# Altium schematic sheet ARK_CAN_GPS_External_Interfaces_Power.SchDoc(ARK_CAN_GPS_External_Interfaces_Power)
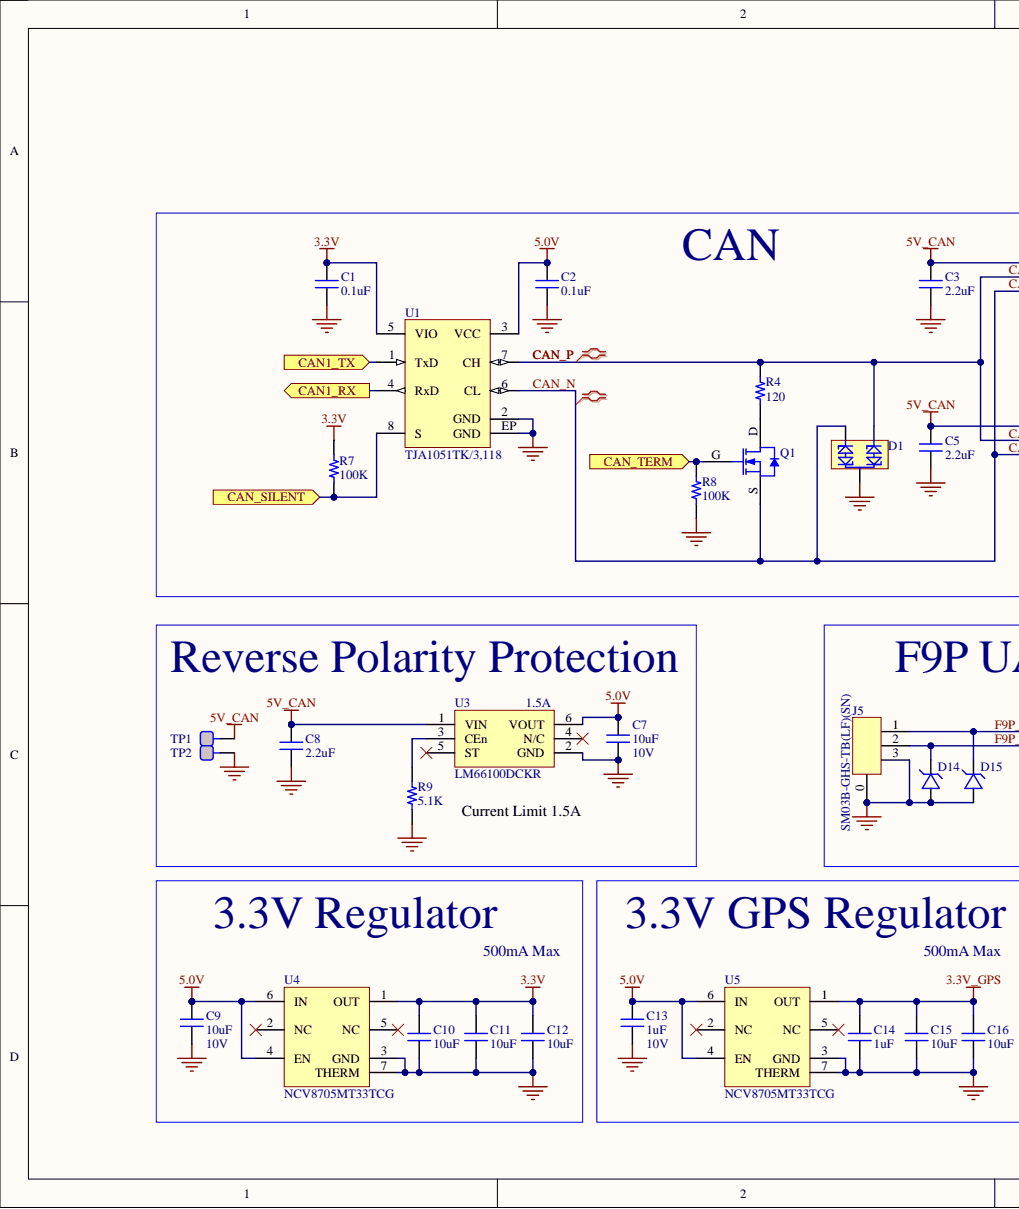
[diagram p0: ARK_CAN_GPS_External_Interfaces_Power.SchDoc, left]
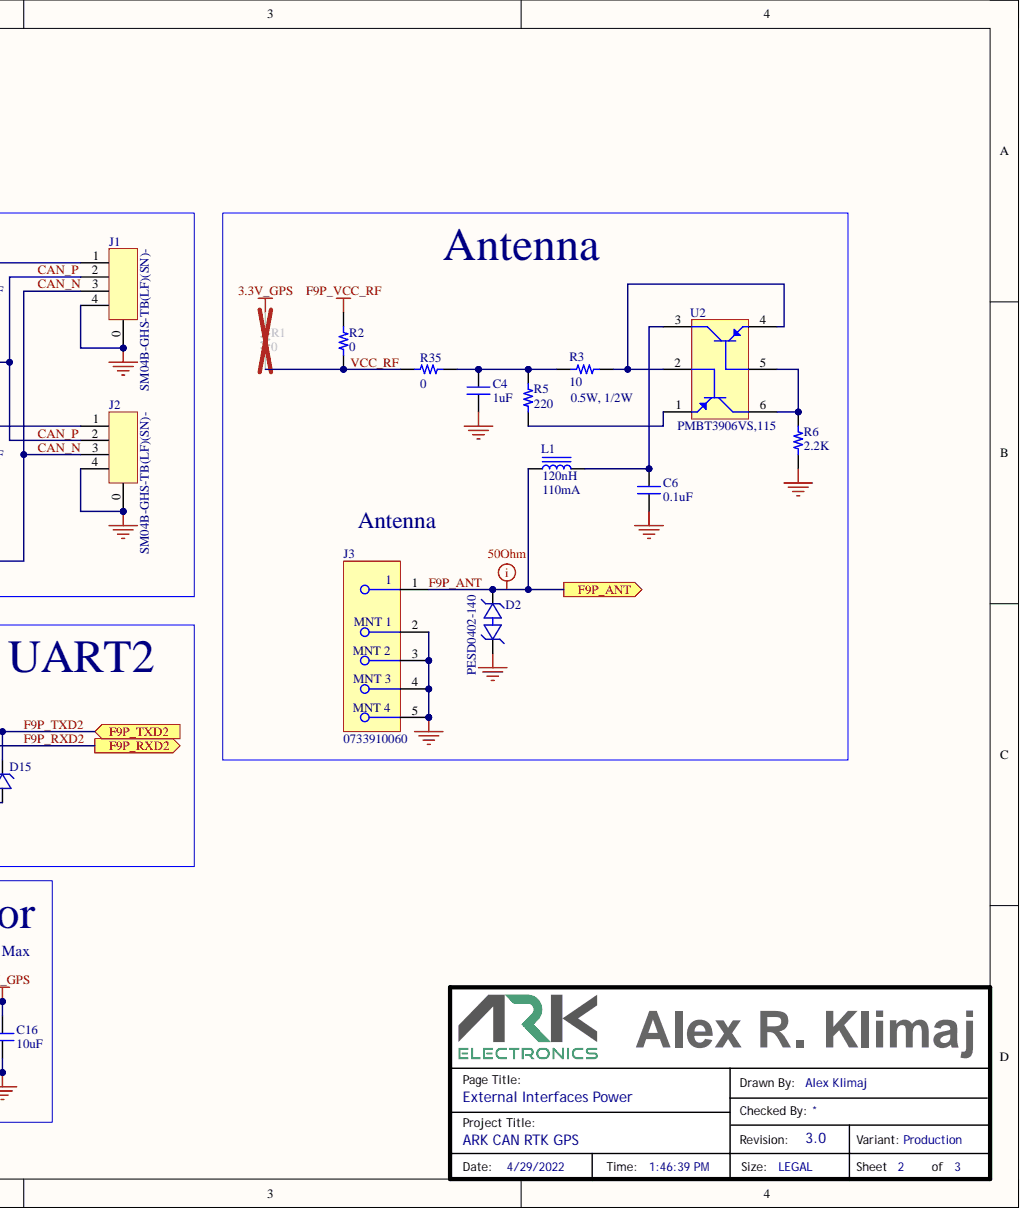
[diagram p1: ARK_CAN_GPS_External_Interfaces_Power.SchDoc, right]

## Parts
C1  value 0.1uF  [CAP 0402/1005]
C2  value 0.1uF  [CAP 0402/1005]
C3  2.2uF  [CAP 0402/1005]
C4  1uF  [CAP 0402/1005]
C5  2.2uF  [CAP 0402/1005]
C6  value 0.1uF  [CAP 0402/1005]
C7  10uF  Voltage_Rating: 10V  [CAP 0402/1005]
C8  2.2uF  [CAP 0402/1005]
C9  10uF  Voltage_Rating: 10V  [CAP 0402/1005]
C10  10uF  [CAP 0402/1005]
C11  10uF  [CAP 0402/1005]
C12  10uF  [CAP 0402/1005]
C13  1uF  Voltage_Rating: 10V  [CAP 0402/1005]
C14  1uF  [CAP 0402/1005]
C15  10uF  [CAP 0402/1005]
C16  10uF  [CAP 0402/1005]
D1  PESD1CAN-UX  [SOT65P210X110-3N]  (value not drawn)
D2  PESD0402-140  [DIOC1005X04N 0402]
D14  (no value)  [SOD-923]
D15  (no value)  [SOD-923]
J1  value SM04B-GHS-TB(LF)(SN)-  Mfr Part Number: SM04B-GHS-TB(LF)(SN)-  [SM04B-GHS]
J2  value SM04B-GHS-TB(LF)(SN)-  Mfr Part Number: SM04B-GHS-TB(LF)(SN)-  [SM04B-GHS]
J3  0733910060  [MOLEX_73391-0060]
J5  SM03B-GHS-TB(LF)(SN)  [SM03B-GHS]
L1  120nH  Current_Rating: 110mA  [Ind 0402/1005]
Q1  DMN53D0L-7  [SOT23 DIODES]  (value not drawn)
R1  0  [RESC0402(1005)_N]
R2  0  [RESC0402(1005)_N]
R3  10  Power_Rating: 0.5W, 1/2W  [C1210_M]
R4  value 120  [RES603L]
R5  220  [RESC0402(1005)_N]
R6  2.2K  [RESC0402(1005)_N]
R7  100K  [RESC0402(1005)_N]
R8  100K  [RESC0402(1005)_N]
R9  value 5.1K  [RESC0402(1005)_N]
R35  0  [RESC0402(1005)_N]
TP1  (no value)  [TEST_PAD]  (no BOM)
TP2  (no value)  [TEST_PAD]  (no BOM)
U1  TJA1051TK/3,118  [8DFN_3x3]
U2  PMBT3906VS,115  [SOT666]
U3  value LM66100DCKR  Current_Rating: 1.5A  Mfr Part Number: LM66100DCKR  [SOT65P210X110-6N]
U4  NCV8705MT33TCG  [WDFN6 2x2, 0.65P]
U5  NCV8705MT33TCG  [WDFN6 2x2, 0.65P]

## Ports
CAN1_RX, CAN1_TX, CAN_SILENT, CAN_TERM, F9P_ANT, F9P_RXD2, F9P_TXD2

## Nets
3.3V: C1.2 C10.2 C11.2 C12.2 R7.2 U1.5(VIO) U4.1(OUT)
3.3V_GPS: C14.2 C15.2 C16.2 R1.2 U5.1(OUT)
5.0V: C2.2 C3.2 C5.2 C7.2 C8.2 C9.2 C13.2 J1.1 J2.1 TP1.1 U1.3(VCC) U3.1(VIN) U3.6(VOUT) U4.4(EN) U4.6(IN) U5.4(EN) U5.6(IN)
F9P_VCC_RF: R2.2
GND: C1.1 C2.1 C3.1 C4.1 C5.1 C6.1 C7.1 C8.1 C9.1 C10.1 C11.1 C12.1 C13.1 C14.1 C15.1 C16.1 D1.3 D2.2 D14.2 D15.2 J1.0 J1.4 J2.0 J2.4 J3.2 J3.3 J3.4 J3.5 J5.0 J5.3 R6.1 R8.1 R9.2 TP2.1 U1.2(GND) U1.EP(GND) U3.2(GND) U4.3(GND) U4.7(THERM) U5.3(GND) U5.7(THERM)
CAN1_RX: U1.4(RxD) ; port CAN1_RX
CAN1_TX: U1.1(TxD) ; port CAN1_TX
CAN_N: D1.1 J1.3 J2.3 Q1.S U1.6(CL)
CAN_P: D1.2 J1.2 J2.2 R4.2 U1.7(CH)
CAN_SILENT: R7.1 U1.8(S) ; port CAN_SILENT
CAN_TERM: Q1.G R8.2 ; port CAN_TERM
F9P_ANT: D2.1 J3.1 L1.1 ; port F9P_ANT
F9P_RXD2: D14.1 J5.2 ; port F9P_RXD2
F9P_TXD2: D15.1 J5.1 ; port F9P_TXD2
VCC_RF: R1.1 R2.1 R35.1
NetC4_2: C4.2 R3.2 R5.2 R35.2
NetC6_2: C6.2 L1.2 U2.3
NetQ1_D: Q1.D R4.1
NetR3_1: R3.1 U2.2 U2.4
NetR5_1: R5.1 U2.1
NetR6_2: R6.2 U2.5 U2.6
NetR9_1: R9.1 U3.3(CEn)

Not connected: U3 4(N/C) 5(ST); U4 2(NC) 5(NC); U5 2(NC) 5(NC)

## Library data of the parts
C1 C2 C6 = 30007: SMT Capacitor; Manufacturer: Walsin Technology Corporation; Mfr Part Description: CAP CER 0.1uF ±10% 25V X5R 0402 (1005 Metric); Mfr Part Number: 0402X104K250CT; Database Table Name: Parts; Dielectric: X5R; Tolerance: ±10%; Updated By: aklimaj; Voltage_Rating: 25V; Component Kind: Standard; ComponentLink1Description: Datasheet; ComponentLink1URL: http://www.passivecomponent.com/wp-content/uploads/2018/10/MLCC.pdf; ComponentLink2Description: Supplier Part Details; ComponentLink2URL: https://www.digikey.com/products/en?keywords=0402X104K250CT; Created_Date: 2017/07/11 17:31:38; ID: 30007; Library Name: Parts.DbLib; LifeCycle: New; Package_Case: 0402 (1005 Metric); Part_Type: Capacitor; RoHS: Yes; Supplier: Digi-Key; Supplier Part Number: 1292-1640-2-ND; Temperature Range: -55C to +85C; Updated_Date: 2020/12/17 20:30:00; Created By: aklimaj
C3 C5 C8 = 30151: SMT Capacitor; Manufacturer: Murata; Manufacturer Alt 1: Taiyo Yuden; Mfr Part Description: CAP CER 2.2uF ±20% 10V X5R 0402 (1005 Metric); Mfr Part Number: GRM155R61A225ME95D; Mfr Part Number Alt 1: LMK105BJ225MV-F; Database Table Name: Parts; Dielectric: X5R; Tolerance: ±20%; Updated By: aklimaj; Voltage_Rating: 10V; Component Kind: Standard; ComponentLink1Description: Datasheet; ComponentLink1URL: http://search.murata.co.jp/Ceramy/image/img/A01X/partnumbering_e_01.pdf; ComponentLink2Description: Supplier Part Details; ComponentLink2URL: https://www.digikey.com/product-detail/en/murata-electronics-north-america/GRM155R61A225ME95D/490-10452-2-ND/5027537; Created_Date: 2017/11/02 14:41:38; ID: 30151; Library Name: Parts.DbLib; LifeCycle: New; Package_Case: 0402 (1005 Metric); Part_Type: Capacitor; RoHS: Yes; Supplier: Digi-Key; Supplier Part Number: 490-10452-2-ND; Temperature Range: -55C to +85C; Updated_Date: 2021/09/10 21:38:25; Value: 2.2uF; Created By: aklimaj
C4 C14 = 30652: SMT Capacitor; Manufacturer: Samsung Electro-Mechanics; Mfr Part Description: CAP CER 1uF ±10% 10V X5R 0402 (1005 Metric); Mfr Part Number: CL05A105KP5NNNC; Database Table Name: Parts; Dielectric: X5R; Tolerance: ±10%; Updated By: aklimaj; Voltage_Rating: 10V; Component Kind: Standard; ComponentLink1Description: Datasheet; ComponentLink1URL: http://www.samsungsem.com/kr/support/product-search/mlcc/CL05A105KP5NNNC.jsp; ComponentLink2Description: Supplier Part Details; ComponentLink2URL: https://www.digikey.com/product-detail/en/0/1276-1076-1-ND; Created_Date: 2019/11/21 13:38:55; ID: 30652; Library Name: Parts.DbLib; LifeCycle: New; Package_Case: 0402 (1005 Metric); Part_Type: Capacitor; RoHS: Yes; Supplier: Digi-Key; Supplier Part Number: 1276-1076-1-ND; Temperature Range: -55C to +85C; Updated_Date: 2020/08/31 12:38:02; Value: 1uF; Created By: aklimaj
C7 C9 = 30418: SMT Capacitor; Manufacturer: Samsung Electro-Mechanics; Mfr Part Description: CAP CER 10uF ±20% 10V X5R 0402 (1005 Metric); Mfr Part Number: CL05A106MP8NUB8; Mfr Part Number Alt 1: CL05A106MP5NUNC; Database Table Name: Parts; Dielectric: X5R; Tolerance: ±20%; Updated By: aklimaj; Component Kind: Standard; ComponentLink1Description: Datasheet; ComponentLink1URL: http://www.samsungsem.com/kr/support/product-search/mlcc/CL05A106MP8NUB8.jsp; ComponentLink2Description: Supplier Part Details; ComponentLink2URL: https://www.digikey.com/product-detail/en/samsung-electro-mechanics/CL05A106MP8NUB8/1276-6830-1-ND/5961689; Created_Date: 2019/04/03 13:12:18; ID: 30418; Library Name: Parts.DbLib; LifeCycle: New; Manufacturer Alt 1: Samsung Electro-Mechanics; Package_Case: 0402 (1005 Metric); Part_Type: Capacitor; RoHS: Yes; Supplier: Digi-Key; Supplier Part Number: 1276-6830-1-ND; Temperature Range: -55C to +85C; Updated_Date: 2021/07/05 13:25:11; Value: 10uF; Created By: aklimaj
C10-C12 C15 C16 = 30418: SMT Capacitor; Manufacturer: Samsung Electro-Mechanics; Mfr Part Description: CAP CER 10uF ±20% 10V X5R 0402 (1005 Metric); Mfr Part Number: CL05A106MP8NUB8; Mfr Part Number Alt 1: CL05A106MP5NUNC; Database Table Name: Parts; Dielectric: X5R; Tolerance: ±20%; Updated By: aklimaj; Voltage_Rating: 10V; Component Kind: Standard; ComponentLink1Description: Datasheet; ComponentLink1URL: http://www.samsungsem.com/kr/support/product-search/mlcc/CL05A106MP8NUB8.jsp; ComponentLink2Description: Supplier Part Details; ComponentLink2URL: https://www.digikey.com/product-detail/en/samsung-electro-mechanics/CL05A106MP8NUB8/1276-6830-1-ND/5961689; Created_Date: 2019/04/03 13:12:18; ID: 30418; Library Name: Parts.DbLib; LifeCycle: New; Manufacturer Alt 1: Samsung Electro-Mechanics; Package_Case: 0402 (1005 Metric); Part_Type: Capacitor; RoHS: Yes; Supplier: Digi-Key; Supplier Part Number: 1276-6830-1-ND; Temperature Range: -55C to +85C; Updated_Date: 2021/07/05 13:25:11; Value: 10uF; Created By: aklimaj
C13 = 30652: SMT Capacitor; Manufacturer: Samsung Electro-Mechanics; Mfr Part Description: CAP CER 1uF ±10% 10V X5R 0402 (1005 Metric); Mfr Part Number: CL05A105KP5NNNC; Database Table Name: Parts; Dielectric: X5R; Tolerance: ±10%; Updated By: aklimaj; Component Kind: Standard; ComponentLink1Description: Datasheet; ComponentLink1URL: http://www.samsungsem.com/kr/support/product-search/mlcc/CL05A105KP5NNNC.jsp; ComponentLink2Description: Supplier Part Details; ComponentLink2URL: https://www.digikey.com/product-detail/en/0/1276-1076-1-ND; Created_Date: 2019/11/21 13:38:55; ID: 30652; Library Name: Parts.DbLib; LifeCycle: New; Package_Case: 0402 (1005 Metric); Part_Type: Capacitor; RoHS: Yes; Supplier: Digi-Key; Supplier Part Number: 1276-1076-1-ND; Temperature Range: -55C to +85C; Updated_Date: 2020/08/31 12:38:02; Value: 1uF; Created By: aklimaj
D1 = 30765: Manufacturer: Nexperia USA Inc.; Mfr Part Description: TVS DIODE 24V 50V SC70-3; Part_Type: Protection; Current_Rating: 3A (8/20µs); Database Table Name: Parts; Updated By: aklimaj; Voltage_Rating: 24V (Max); Component Kind: Standard; ComponentLink1Description: Datasheet; ComponentLink1URL: https://assets.nexperia.com/documents/data-sheet/PESD1CAN-U.pdf; ComponentLink2Description: Supplier Part Details; ComponentLink2URL: https://www.digikey.com/product-detail/en/nexperia-usa-inc/PESD1CAN-UX/1727-1306-1-ND/4386316; Created_Date: 2020/04/14 12:26:16; ID: 30765; Library Name: Parts.DbLib; LifeCycle: New; Mfr Part Number: PESD1CAN-UX; Package_Case: SC-70, SOT-323; RoHS: Yes; Supplier: Digi-Key; Supplier Part Number: 1727-1306-1-ND; Temperature Range: -55C to +150C (TA); Updated_Date: 2020/04/14 12:34:02; Value: PESD1CAN-UX; Created By: aklimaj
D2 = 30446: TVS Diode; Manufacturer: Littelfuse Inc.; Mfr Part Description: TVS DIODE 14V 40V 0402; Part_Type: Protection; Database Table Name: Parts; Updated By: aklimaj; Voltage_Rating: 14V (Max); Component Kind: Standard; ComponentLink1Description: Datasheet; ComponentLink1URL: https://www.littelfuse.com/~/media/electronics/product_specifications/polymer_esd_suppressors/littelfuse_polymer_esd_suppressor_pesd0402_140_product_specification.pdf.pdf; ComponentLink2Description: Supplier Part Details; ComponentLink2URL: https://www.digikey.com/product-detail/en/littelfuse-inc/PESD0402-140/PESD0402-140CT-ND/1968526; Created_Date: 2019/05/07 17:26:56; ID: 30446; Library Name: Parts.DbLib; LifeCycle: New; Mfr Part Number: PESD0402-140; Package_Case: 0402 (1005 Metric); RoHS: Yes; Supplier: Digi-Key; Supplier Part Number: PESD0402-140CT-ND; Temperature Range: -55C to +125C (TJ); Updated_Date: 2019/11/30 16:11:35; Value: PESD0402-140; Created By: aklimaj
D14 D15 = 30166: TVS Diode; Manufacturer: ON Semiconductor; Mfr Part Description: TVS DIODE 3.3VWM SOD923; Mfr Part Number: ESD9C3.3ST5G; Part_Type: Protection; Database Table Name: Parts; Updated By: aklimaj; Value: ESD9C3.3ST5G; Voltage_Rating: 3.3V (Max); Component Kind: Standard; ComponentLink1Description: Datasheet; ComponentLink1URL: http://www.onsemi.com/pub/Collateral/ESD9C3.3S-D.PDF; ComponentLink2Description: Supplier Part Details; ComponentLink2URL: https://www.digikey.com/product-detail/en/on-semiconductor/ESD9C3.3ST5G/ESD9C3.3ST5GOSCT-ND/1646498; Created_Date: 2017/12/13 10:54:56; ID: 30166; Library Name: Parts.DbLib; LifeCycle: New; Package_Case: SOD-923; RoHS: Yes; Supplier: Digi-Key; Supplier Part Number: ESD9C3.3ST5GOSCT-ND; Temperature Range: -55C to +150C (TJ); Updated_Date: 2018/10/19 21:38:12; Created By: aklimaj
J1 J2 = 30106: CONN HEADER GH SIDE 4POS 1.25MM; Manufacturer: JST Sales America Inc.; Part_Type: Connector; Current_Rating: 1A; Database Table Name: Parts; Updated By: aklimaj; Voltage_Rating: 50V; Component Kind: Standard; ComponentLink1Description: Datasheet; ComponentLink1URL: http://www.jst-mfg.com/product/pdf/eng/eGH.pdf; ComponentLink2Description: Supplier Part Details; ComponentLink2URL: https://www.digikey.com/product-detail/en/jst-sales-america-inc/SM04B-GHS-TB-LF-SN/455-1566-1-ND/807834; Created_Date: 2017/09/21 14:10:07; ID: 30106; Library Name: Parts.DbLib; LifeCycle: New; Mfr Part Description: CONN HEADER GH SIDE 4POS 1.25MM; RoHS: Yes; Supplier: Digi-Key; Supplier Part Number: 455-1566-1-ND; Temperature Range: -25C to +85C; Updated_Date: 2018/10/19 21:38:27; Created By: aklimaj
J3 = 31030: Manufacturer: Molex; Mfr Part Description: CONN SMA RCPT STR 50 OHM PCB; Part_Type: Connector; Database Table Name: Parts; Updated By: aklimaj; Voltage_Rating: 500 V; Component Kind: Standard; ComponentLink1Description: Datasheet; ComponentLink1URL: https://www.molex.com/pdm_docs/ps/PS-89675-346.pdf; ComponentLink2Description: Supplier Part Details; ComponentLink2URL: https://www.digikey.com/products/en?keywords=0733910060; Created_Date: 2021/04/01 12:03:55; ID: 31030; Library Name: Parts.DbLib; LifeCycle: New; Mfr Part Number: 0733910060; RoHS: Yes; Supplier: Digi-Key; Supplier Part Number: WM5543-ND; Temperature Range: -65C to +165C; Updated_Date: 2021/04/01 12:13:59; Value: 0733910060; Created By: aklimaj
J5 = 30548: Manufacturer: JST Sales America Inc.; Mfr Part Description: Connector Header Surface Mount, Right Angle 3 position 0.049" (1.25mm); Part_Type: Connector; Current_Rating: 1A; Database Table Name: Parts; Updated By: aklimaj; Voltage_Rating: 50V; Component Kind: Standard; ComponentLink1Description: Datasheet; ComponentLink1URL: http://www.jst-mfg.com/product/pdf/eng/eGH.pdf; ComponentLink2Description: Supplier Part Details; ComponentLink2URL: https://www.digikey.com/product-detail/en/jst-sales-america-inc/SM03B-GHS-TB-LF-SN/455-1565-1-ND/807833; Created_Date: 2019/10/09 17:19:18; ID: 30548; Library Name: Parts.DbLib; LifeCycle: New; Mfr Part Number: SM03B-GHS-TB(LF)(SN); RoHS: Yes; Supplier: Digi-Key; Supplier Part Number: 455-1565-1-ND; Temperature Range: -25C to +85C; Updated_Date: 2019/10/09 17:32:45; Value: SM03B-GHS-TB(LF)(SN); Created By: aklimaj
L1 = 30445: SMT Inductor; Manufacturer: Murata Electronics North America; Mfr Part Description: INDUCTOR 120nH ±5% 110mA 0402 (1005 Metric); Mfr Part Number: LQW15ANR12J00D; Database Table Name: Parts; Tolerance: ±5%; Updated By: aklimaj; Component Kind: Standard; ComponentLink1Description: Datasheet; ComponentLink1URL: https://search.murata.co.jp/Ceramy/image/img/P02/JELF243A-0050.pdf; ComponentLink2Description: Supplier Part Details; ComponentLink2URL: https://www.digikey.com/product-detail/en/murata-electronics-north-america/LQW15ANR12J00D/490-4091-1-ND/1016351; Created_Date: 2019/05/07 17:16:34; ID: 30445; Library Name: Parts.DbLib; LifeCycle: New; Package_Case: 0402 (1005 Metric); Part_Type: Inductor; RoHS: Yes; Supplier: Digi-Key; Supplier Part Number: 490-4091-1-ND; Temperature Range: -55C to +125C; Updated_Date: 2019/05/07 17:22:22; Value: 120nH; Created By: aklimaj
Q1 = 31111: Manufacturer: Diodes Incorporated; Mfr Part Description: MOSFET N-CH 50V 500MA SOT23; Part_Type: Discrete; Current_Rating: 500mA (Ta); Database Table Name: Parts; Updated By: aklimaj; Voltage_Rating: 2.5V, 10V; Component Kind: Standard; ComponentLink1Description: Datasheet; ComponentLink1URL: https://www.diodes.com/assets/Datasheets/DMN53D0L.pdf; ComponentLink2Description: Supplier Part Details; ComponentLink2URL: https://www.digikey.com/en/products/detail/diodes-incorporated/DMN53D0L-7/4934983; Created_Date: 2021/07/13 17:36:24; ID: 31111; Library Name: Parts.DbLib; LifeCycle: New; Mfr Part Number: DMN53D0L-7; Package_Case: TO-236-3, SC-59, SOT-23-3; RoHS: Yes; Supplier: Digi-Key; Supplier Part Number: DMN53D0L-7DITR-ND; Temperature Range: -55C to +150C (TJ); Updated_Date: 2021/07/13 17:36:30; Value: DMN53D0L-7; Created By: aklimaj
R1 R2 R35 = 30327: SMT Resistor; Manufacturer: Yageo; Manufacturer Alt 1: Panasonic Electronic Components; Mfr Part Description: RES 0 Ohm Jumper 1/16W 0402 (1005 Metric); Mfr Part Number: AC0402JR-070RL; Mfr Part Number Alt 1: ERJ-2GE0R00X; Database Table Name: Parts; Power_Rating: 0.063W, 1/16W; Tolerance: Jumper; Updated By: aklimaj; Component Kind: Standard; ComponentLink1Description: Datasheet; ComponentLink1URL: https://www.yageo.com/upload/media/product/productsearch/datasheet/rchip/PYu-AC_51_RoHS_L_7.pdf; ComponentLink2Description: Supplier Part Details; ComponentLink2URL: https://www.digikey.com/product-detail/en/AC0402JR-070RL/311-0.0LBCT-ND; Created_Date: 2019/02/28 18:42:38; ID: 30327; Library Name: Parts.DbLib; LifeCycle: New; Manufacturer Alt 2: Stackpole Electronics Inc; Mfr Part Number Alt 2: RMCF0402ZT0R00; Package_Case: 0402 (1005 Metric); Part_Type: Resistor; RoHS: Yes; Supplier: Digi-Key; Supplier Part Number: 311-0.0LBCT-ND; Temperature Range: -55C to +155C; Updated_Date: 2021/07/12 11:57:52; Value: 0; Created By: aklimaj
R3 = 30452: SMT Resistor; Manufacturer: Stackpole Electronics Inc; Mfr Part Description: RES 10 Ohm ±5% 1/2W 1210 (3225 Metric); Mfr Part Number: RMCF1210JT10R0; Database Table Name: Parts; Temperature Coeff: ±200ppm/degC; Tolerance: ±5%; Updated By: aklimaj; Component Kind: Standard; ComponentLink1Description: Datasheet; ComponentLink1URL: https://www.seielect.com/catalog/sei-rmcf_rmcp.pdf; ComponentLink2Description: Supplier Part Details; ComponentLink2URL: https://www.digikey.com/product-detail/en/stackpole-electronics-inc/RMCF1210JT10R0/RMCF1210JT10R0CT-ND/1942842; Created_Date: 2019/05/08 15:49:04; ID: 30452; Library Name: Parts.DbLib; LifeCycle: New; Package_Case: 1210 (3225 Metric); Part_Type: Resistor; RoHS: Yes; Supplier: Digi-Key; Supplier Part Number: RMCF1210JT10R0CT-ND; Temperature Range: -55C to +155C; Updated_Date: 2019/05/08 15:52:46; Value: 10; Created By: aklimaj
R4 = 30956: SMT Resistor; Manufacturer: Stackpole Electronics Inc; Mfr Part Description: RES 120 Ohm ±1% 1/10W 0603 (1608 Metric); Mfr Part Number: RMCF0603FT120R; Database Table Name: Parts; Power_Rating: 0.1W, 1/10W; Temperature Coeff: ±100ppm/degC; Tolerance: ±1%; Updated By: aklimaj; Component Kind: Standard; ComponentLink1Description: Datasheet; ComponentLink1URL: https://www.seielect.com/catalog/sei-rmcf_rmcp.pdf; ComponentLink2Description: Supplier Part Details; ComponentLink2URL: https://www.digikey.com/products/en?keywords=RMCF0603FT120R; Created_Date: 2020/12/23 11:13:51; ID: 30956; Library Name: Parts.DbLib; LifeCycle: New; Package_Case: 0603 (1608 Metric); Part_Type: Resistor; RoHS: Yes; Supplier: Digi-Key; Supplier Part Number: RMCF0603FT120RTR-ND; Temperature Range: -55C to +155C; Updated_Date: 2020/12/23 11:14:13; Created By: aklimaj
R5 = 30490: SMT Resistor; Manufacturer: Stackpole Electronics Inc; Mfr Part Description: RES 220 Ohm ±5% 1/16W 0402 (1005 Metric); Mfr Part Number: RMCF0402JT220R; Database Table Name: Parts; Power_Rating: 0.063W, 1/16W; Temperature Coeff: ±100ppm/degC; Tolerance: ±5%; Updated By: aklimaj; Component Kind: Standard; ComponentLink1Description: Datasheet; ComponentLink1URL: https://www.seielect.com/catalog/sei-rmcf_rmcp.pdf; ComponentLink2Description: Supplier Part Details; ComponentLink2URL: https://www.digikey.com/product-detail/en/stackpole-electronics-inc/RMCF0402JT220R/RMCF0402JT220RCT-ND/2417855; Created_Date: 2019/07/03 12:15:03; ID: 30490; Library Name: Parts.DbLib; LifeCycle: New; Package_Case: 0402 (1005 Metric); Part_Type: Resistor; RoHS: Yes; Supplier: Digi-Key; Supplier Part Number: RMCF0402JT220RCT-ND; Temperature Range: -55C to +155C; Updated_Date: 2019/07/03 12:15:37; Value: 220; Created By: aklimaj
R6 = 30330: SMT Resistor; Manufacturer: Yageo; Mfr Part Description: RES 2.2K Ohm ±1% 1/16W 0402 (1005 Metric); Mfr Part Number: AC0402FR-072K2L; Database Table Name: Parts; Power_Rating: 0.063W, 1/16W; Temperature Coeff: ±100ppm/degC; Tolerance: ±1%; Updated By: aklimaj; Component Kind: Standard; ComponentLink1Description: Datasheet; ComponentLink1URL: http://www.yageo.com/NewPortal/yageodocoutput?fileName=/pdf/R-Chip/PYu-AC_51_RoHS_L_6.pdf; ComponentLink2Description: Supplier Part Details; ComponentLink2URL: https://www.digikey.com/products/en?keywords=YAG3467CT-ND&stock=1; Created_Date: 2019/02/28 18:47:29; ID: 30330; Library Name: Parts.DbLib; LifeCycle: New; Package_Case: 0402 (1005 Metric); Part_Type: Resistor; RoHS: Yes; Supplier: Digi-Key; Supplier Part Number: YAG3467CT-ND; Temperature Range: -55C to +155C; Updated_Date: 2019/02/28 18:47:44; Value: 2.2K; Created By: aklimaj
R7 R8 = 30333: SMT Resistor; Manufacturer: Yageo; Mfr Part Description: RES 100K Ohm ±5% 1/16W 0402 (1005 Metric); Mfr Part Number: AC0402JR-07100KL; Database Table Name: Parts; Power_Rating: 0.063W, 1/16W; Temperature Coeff: ±100ppm/degC; Tolerance: ±5%; Updated By: aklimaj; Component Kind: Standard; ComponentLink1Description: Datasheet; ComponentLink1URL: http://www.yageo.com/NewPortal/yageodocoutput?fileName=/pdf/R-Chip/PYu-AC_51_RoHS_L_6.pdf; ComponentLink2Description: Supplier Part Details; ComponentLink2URL: https://www.digikey.com/products/en?keywords=YAG3515CT-ND&stock=1; Created_Date: 2019/02/28 18:55:55; ID: 30333; Library Name: Parts.DbLib; LifeCycle: New; Package_Case: 0402 (1005 Metric); Part_Type: Resistor; RoHS: Yes; Supplier: Digi-Key; Supplier Part Number: YAG3515CT-ND; Temperature Range: -55C to +155C; Updated_Date: 2019/02/28 18:56:10; Value: 100K; Created By: aklimaj
R9 = 30207: SMT Resistor; Manufacturer: Yageo; Mfr Part Description: RES 5.1K Ohm ±1% 1/16W 0402 (1005 Metric); Mfr Part Number: RC0402FR-075K1L; Database Table Name: Parts; Power_Rating: 0.063W, 1/16W; Temperature Coeff: ±100ppm/degC; Tolerance: ±1%; Updated By: aklimaj; Component Kind: Standard; ComponentLink1Description: Datasheet; ComponentLink1URL: http://www.yageo.com/documents/recent/PYu-RC_Group_51_RoHS_L_9.pdf; ComponentLink2Description: Supplier Part Details; ComponentLink2URL: https://www.digikey.com/product-detail/en/yageo/RC0402FR-075K1L/311-5.10KLRCT-ND/729571; Created_Date: 2018/05/21 18:16:47; ID: 30207; Library Name: Parts.DbLib; LifeCycle: New; Package_Case: 0402 (1005 Metric); Part_Type: Resistor; RoHS: Yes; Supplier: Digi-Key; Supplier Part Number: 311-5.10KLRCT-ND; Temperature Range: -55C to +155C; Updated_Date: 2018/10/19 21:38:02; Created By: aklimaj
TP1 TP2 = 30015: TEST_PAD; Mfr Part Description: Test Point; Database Table Name: Parts; Updated By: aklimaj; ComponentLink1Description: Datasheet; ComponentLink2Description: Supplier Part Details; Created_Date: 2017/07/13 09:26:35; ID: 30015; Library Name: Parts.DbLib; LifeCycle: New; Part_Type: Test Point; RoHS: Yes; Updated_Date: 2019/07/19 12:21:04; Created By: aklimaj
U1 = 30095: IC CAN TRANSEIVER HS 8HVSON; Manufacturer: NXP USA Inc.; Manufacturer Alt 1: Microchip; Mfr Part Number Alt 1: MCP2562FD-H/MF; Database Table Name: Parts; Updated By: aklimaj; Component Kind: Standard; ComponentLink1Description: Datasheet; ComponentLink1URL: http://www.nxp.com/documents/data_sheet/TJA1051.pdf; ComponentLink2Description: Supplier Part Details; ComponentLink2URL: https://www.digikey.com/product-detail/en/nxp-usa-inc/TJA1051TK-3,118/568-8686-1-ND/2803572; Created_Date: 2017/09/13 12:36:12; ID: 30095; Library Name: Parts.DbLib; LifeCycle: New; Mfr Part Description: IC CAN TRANSEIVER HS 8HVSON; Mfr Part Number: TJA1051TK/3,118; Package_Case: 8-VDFN Exposed Pad; Part_Type: IC; RoHS: Yes; Supplier: Digi-Key; Supplier Part Number: 568-8686-1-ND; Temperature Range: -40C to +150C; Updated_Date: 2021/03/29 09:09:18; Value: TJA1051TK/3,118; Created By: aklimaj
U2 = 30489: Manufacturer: Nexperia USA Inc.; Mfr Part Description: TRANS 2PNP 40V 0.2A SOT666; Part_Type: Discrete; Current_Rating: 200mA; Database Table Name: Parts; Updated By: aklimaj; Voltage_Rating: 40V; Component Kind: Standard; ComponentLink1Description: Datasheet; ComponentLink1URL: https://assets.nexperia.com/documents/data-sheet/PMBT3906VS.pdf; ComponentLink2Description: Supplier Part Details; ComponentLink2URL: https://www.digikey.com/product-detail/en/nexperia-usa-inc/PMBT3906VS115/1727-5179-1-ND/2531777; Created_Date: 2019/07/03 12:00:32; ID: 30489; Library Name: Parts.DbLib; LifeCycle: New; Mfr Part Number: PMBT3906VS,115; Package_Case: SOT-563, SOT-666; RoHS: Yes; Supplier: Digi-Key; Supplier Part Number: 1727-5179-1-ND; Temperature Range: 150C (TJ); Updated_Date: 2019/07/03 12:00:46; Value: PMBT3906VS,115; Created By: aklimaj
U3 = 30951: Manufacturer: Texas Instruments; Mfr Part Description: IC OR CTRLR IDEAL DIODE SC70-6; Part_Type: IC; Database Table Name: Parts; Updated By: aklimaj; Voltage_Rating: 5.5V; Component Kind: Standard; ComponentLink1Description: Datasheet; ComponentLink1URL: http://www.ti.com/general/docs/suppproductinfo.tsp?distId=10&amp;gotoUrl=http%3A%2F%2Fwww.ti.com%2Flit%2Fgpn%2flm66100; ComponentLink2Description: Supplier Part Details; ComponentLink2URL: https://www.digikey.com/products/en?keywords=LM66100DCKR; Created_Date: 2020/12/22 17:48:20; ID: 30951; Library Name: Parts.DbLib; LifeCycle: New; Package_Case: 6-TSSOP, SC-88, SOT-363; RoHS: Yes; Supplier: Digi-Key; Supplier Part Number: 296-53541-2-ND; Temperature Range: -40C to +105C; Updated_Date: 2020/12/22 17:55:00; Created By: aklimaj
U4 U5 = 30451: Manufacturer: ON Semiconductor; Mfr Part Description: IC REG LINEAR 3.3V 500MA 6WDFN; Part_Type: IC; Database Table Name: Parts; Sub_Type: REG; Updated By: aklimaj; Component Kind: Standard; ComponentLink1Description: Datasheet; ComponentLink1URL: http://www.onsemi.com/pub/Collateral/NCV8705-D.PDF; ComponentLink2Description: Supplier Part Details; ComponentLink2URL: https://www.digikey.com/product-detail/en/on-semiconductor/NCV8705MT33TCG/NCV8705MT33TCGOSCT-ND/8538675; Created_Date: 2019/05/08 11:35:43; ID: 30451; Library Name: Parts.DbLib; LifeCycle: New; Mfr Part Number: NCV8705MT33TCG; Package_Case: 6-WDFN Exposed Pad; RoHS: Yes; Supplier: Digi-Key; Supplier Part Number: NCV8705MT33TCGOSCT-ND; Temperature Range: -40C to +125C (TJ); Updated_Date: 2019/05/08 11:48:17; Value: NCV8705MT33TCG; Created By: aklimaj
